# Altium schematic sheet 5.04.51805A02_RKUsb.SchDoc(5.04.51805A02_RKUsb)
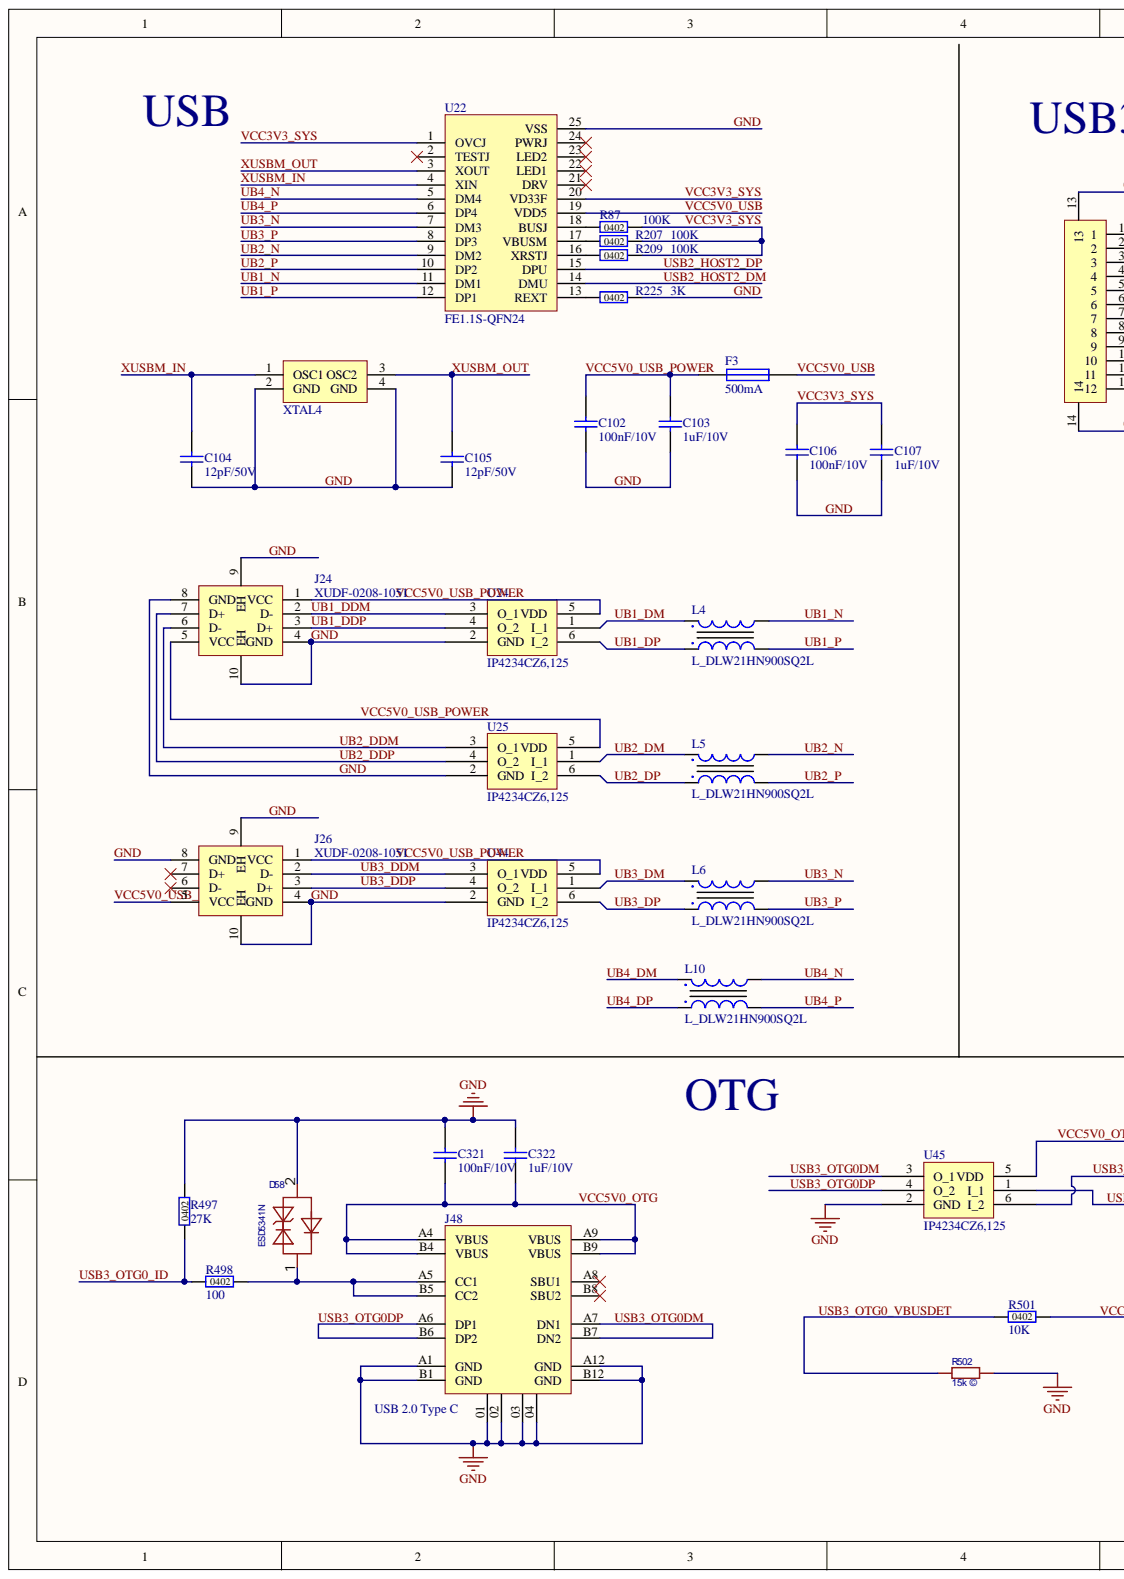
[diagram p0: 5.04.51805A02_RKUsb.SchDoc, left]
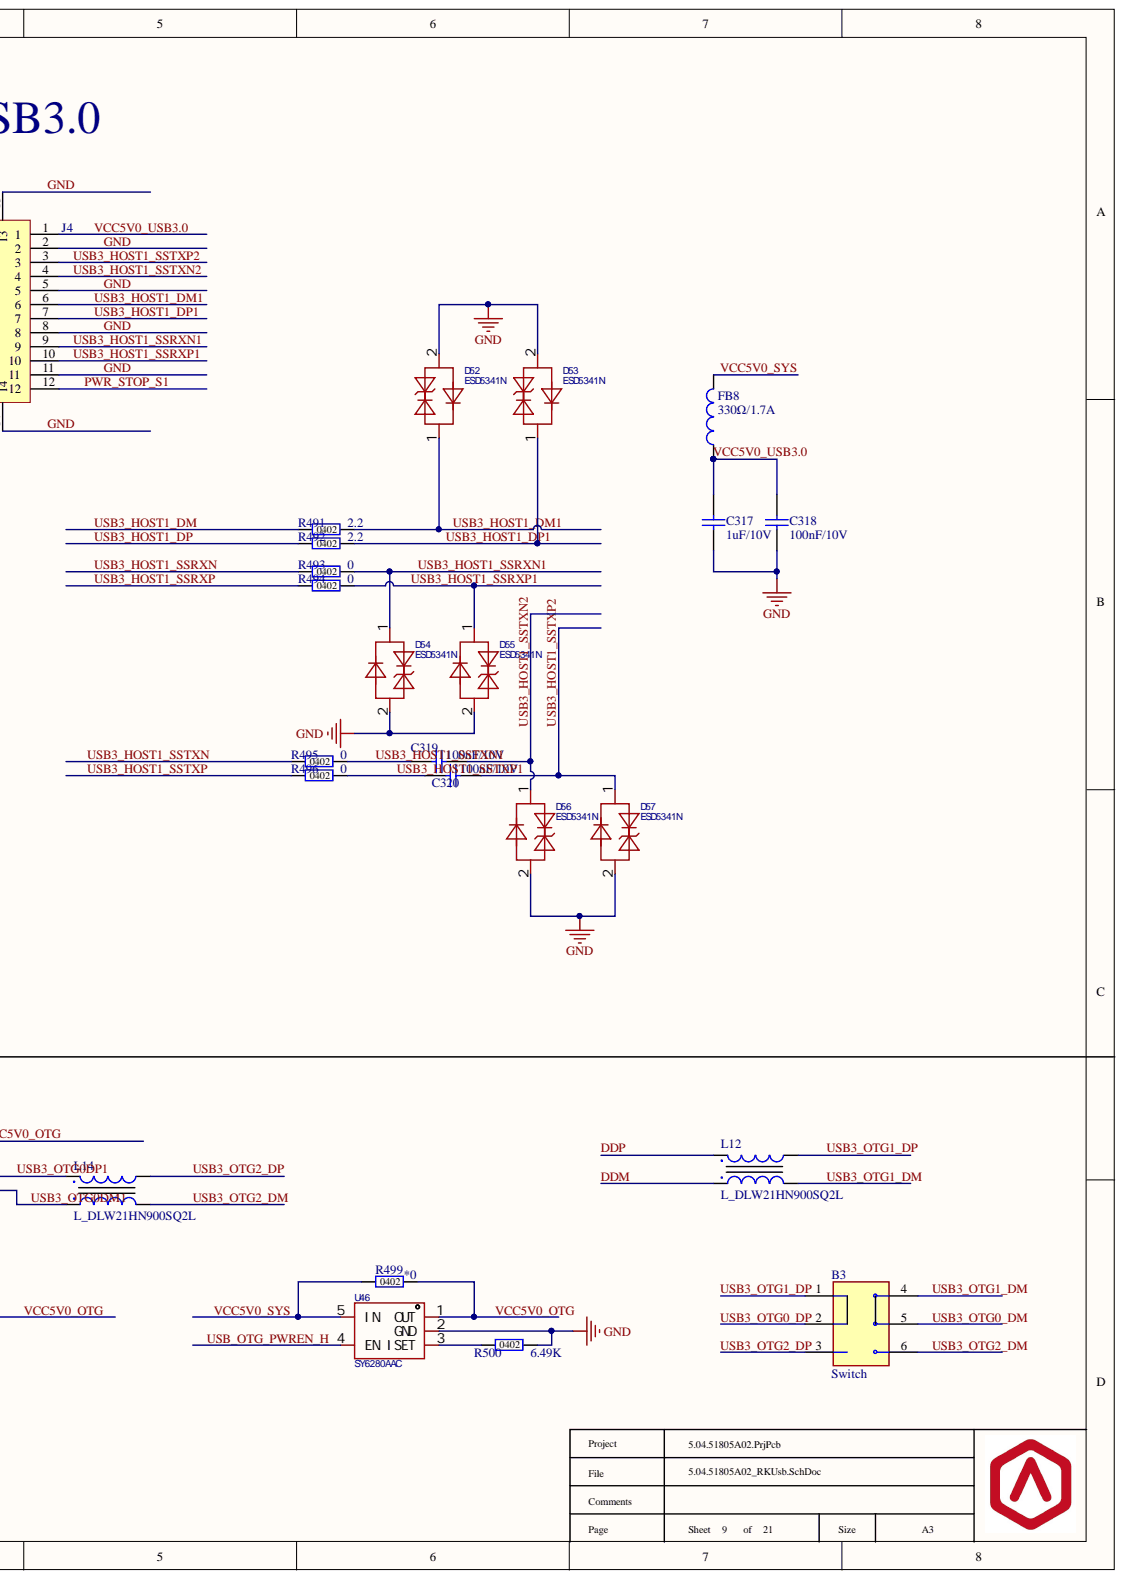
[diagram p1: 5.04.51805A02_RKUsb.SchDoc, right]

## Parts
B3  Switch  [SW-SMD_6P-L7.2-W3.5-P2.50-LS6.0-BL]
C102  100nF/10V  [C0402]
C103  1uF/10V  [C0402]
C104  12pF/50V  [C0402]
C105  12pF/50V  [C0402]
C106  100nF/10V  [C0402]
C107  1uF/10V  [C0402]
C317  1uF/10V  [C0402]
C318  100nF/10V  [C0402]
C319  100nF/10V  [C0402]
C320  100nF/10V  [C0402]
C321  100nF/10V  [C0402]
C322  1uF/10V  [C0402]
D52  ESD5341N  [DFN1006-2L-RD]
D53  ESD5341N  [DFN1006-2L-RD]
D54  ESD5341N  [DFN1006-2L-RD]
D55  ESD5341N  [DFN1006-2L-RD]
D56  ESD5341N  [DFN1006-2L-RD]
D57  ESD5341N  [DFN1006-2L-RD]
D58  ESD5341N  [DFN1006-2L-RD]
F3  500mA  [F1206]
FB8  330Ω/1.7A  [L0603]
J4  AFC01-S12FCA-00  [FPC-SMD_AFC01-S12FCA-00]  (value not drawn)
J24  XUDF-0208-1051  [USB-A-TH_XUDF-0208-1051]
J26  XUDF-0208-1051  [USB-A-TH_XUDF-0208-1051]
J48  USB 2.0 Type C  [USB-Type-C]
L4  L_DLW21HN900SQ2L  [DLW21HN900SQ2L]
L5  L_DLW21HN900SQ2L  [DLW21HN900SQ2L]
L6  L_DLW21HN900SQ2L  [DLW21HN900SQ2L]
L10  L_DLW21HN900SQ2L  [DLW21HN900SQ2L]
L12  L_DLW21HN900SQ2L  [DLW21HN900SQ2L]
L14  L_DLW21HN900SQ2L  [DLW21HN900SQ2L]
R87  100K  [R0402]
R207  100K  [R0402]
R209  100K  [R0402]
R225  3K  [R0402]
R491  2.2  [R0402]
R492  2.2  [R0402]
R493  0  [R0402]
R494  0  [R0402]
R495  0  [R0402]
R496  0  [R0402]
R497  27K  [R0402]
R498  100  [R0402]
R499  *0  [R0402]
R500  6.49K  [R0402]
R501  10K  [R0402]
R502  15kΩ  [R0402]
U22  FE1.1S-QFN24  [WQFN-24_L4.0-W4.0-P0.50-TL-EP2.7]
U24  IP4234CZ6,125  [TSOP6]
U25  IP4234CZ6,125  [TSOP6]
U44  IP4234CZ6,125  [TSOP6]
U45  IP4234CZ6,125  [TSOP6]
U46  SY6280AAC  [SOT-23-5_L3.0-W1.7-P0.95-LS2.8-BR]
XTAL4  X322512MOB4SI  [CRYSTAL-SMD_4P-L3.2-W2.5-BL]  (value not drawn)

## Nets
DDM: L12.1
DDP: L12.4
GND: C102.1 C103.1 C104.1 C105.1 C106.1 C107.1 C317.1 C318.1 C321.2 C322.2 D52.2 D53.2 D54.2 D55.2 D56.2 D57.2 D58.2 J4.2 J4.5 J4.8 J4.11 J4.13 J4.14 J24.4(GND) J24.8(GND) J24.9(EH) J24.10(EH) J26.4(GND) J26.8(GND) J26.9(EH) J26.10(EH) J48.01 J48.02 J48.03 J48.04 J48.A1(GND) J48.A12(GND) J48.B1(GND) J48.B12(GND) R225.2 R497.2 R500.2 R502.2 U22.25(VSS) U24.2(GND) U25.2(GND) U44.2(GND) U45.2(GND) U46.2(GND) XTAL4.2(GND) XTAL4.4(GND)
PWR_STOP_S1: J4.12
UB1_DDM: J24.2(D-) U24.3(O_1)
UB1_DDP: J24.3(D+) U24.4(O_2)
UB1_DM: L4.4 U24.1(I_1)
UB1_DP: L4.1 U24.6(I_2)
UB1_N: L4.3 U22.11(DM1)
UB1_P: L4.2 U22.12(DP1)
UB2_DDM: J24.6(D-) U25.3(O_1)
UB2_DDP: J24.7(D+) U25.4(O_2)
UB2_DM: L5.4 U25.1(I_1)
UB2_DP: L5.1 U25.6(I_2)
UB2_N: L5.3 U22.9(DM2)
UB2_P: L5.2 U22.10(DP2)
UB3_DDM: J26.2(D-) U44.3(O_1)
UB3_DDP: J26.3(D+) U44.4(O_2)
UB3_DM: L6.4 U44.1(I_1)
UB3_DP: L6.1 U44.6(I_2)
UB3_N: L6.3 U22.7(DM3)
UB3_P: L6.2 U22.8(DP3)
UB4_DM: L10.4
UB4_DP: L10.1
UB4_N: L10.3 U22.5(DM4)
UB4_P: L10.2 U22.6(DP4)
USB2_HOST2_DM: U22.14(DMU)
USB2_HOST2_DP: U22.15(DPU)
USB3_HOST1_DM: R491.1
USB3_HOST1_DM1: D52.1 J4.6 R491.2
USB3_HOST1_DP: R492.1
USB3_HOST1_DP1: D53.1 J4.7 R492.2
USB3_HOST1_SSRXN: R493.1
USB3_HOST1_SSRXN1: D54.1 J4.9 R493.2
USB3_HOST1_SSRXP: R494.1
USB3_HOST1_SSRXP1: D55.1 J4.10 R494.2
USB3_HOST1_SSTXN: R495.1
USB3_HOST1_SSTXN1: C319.1 R495.2
USB3_HOST1_SSTXN2: C319.2 D56.1 J4.4
USB3_HOST1_SSTXP: R496.1
USB3_HOST1_SSTXP1: C320.1 R496.2
USB3_HOST1_SSTXP2: C320.2 D57.1 J4.3
USB3_OTG0_DM: B3.5
USB3_OTG0_DP: B3.2
USB3_OTG0_ID: R497.1 R498.1
USB3_OTG0_VBUSDET: R501.1 R502.1
USB3_OTG0DM: J48.A7(DN1) J48.B7(DN2) U45.3(O_1)
USB3_OTG0DM1: L14.4 U45.1(I_1)
USB3_OTG0DP: J48.A6(DP1) J48.B6(DP2) U45.4(O_2)
USB3_OTG0DP1: L14.1 U45.6(I_2)
USB3_OTG1_DM: B3.4 L12.2
USB3_OTG1_DP: B3.1 L12.3
USB3_OTG2_DM: B3.6 L14.3
USB3_OTG2_DP: B3.3 L14.2
USB_OTG_PWREN_H: U46.4(EN)
VCC3V3_SYS: C106.2 C107.2 R87.2 R207.2 R209.2 U22.1(OVCJ) U22.20(VD33F)
VCC5V0_OTG: C321.1 C322.1 J48.A4(VBUS) J48.A9(VBUS) J48.B4(VBUS) J48.B9(VBUS) R499.2 R501.2 U45.5(VDD) U46.1(OUT)
VCC5V0_SYS: FB8.2 R499.1 U46.5(IN)
VCC5V0_USB: F3.2 U22.19(VDD5)
VCC5V0_USB3.0: C317.2 C318.2 FB8.1 J4.1
VCC5V0_USB_POWER: C102.2 C103.2 F3.1 J24.1(VCC) J24.5(VCC) J26.1(VCC) J26.5(VCC) U24.5(VDD) U25.5(VDD) U44.5(VDD)
XUSBM_IN: C104.2 U22.4(XIN) XTAL4.1(OSC1)
XUSBM_OUT: C105.2 U22.3(XOUT) XTAL4.3(OSC2)
NetD58_1: D58.1 J48.A5(CC1) J48.B5(CC2) R498.2
NetR87_1: R87.1 U22.18(BUSJ)
NetR207_1: R207.1 U22.17(VBUSM)
NetR209_1: R209.1 U22.16(XRSTJ)
NetR225_1: R225.1 U22.13(REXT)
NetR500_1: R500.1 U46.3(ISET)

Not connected: J26 6(D-) 7(D+); J48 A8(SBU1) B8(SBU2); U22 2(TESTJ) 21(DRV) 22(LED1) 23(LED2) 24(PWRJ)

## Library data of the parts
B3 = SS-3390S-L2: 开关,SS-3390S-L2,DC24V50mA,100mOhm,拨码,垂直,SMD,-40°C~85°C; Manufacturer1: XKB; Code: 570100XX; Component Kind: Standard; ManufacturerPartNumber1: SS-3390S-L2; Library Name: Raise3D_Switch&Optocoupler.IntLib
C102 C106 C318-C321 = C0402_100nF_10V: Ceramic Capacitor, 100nF, ±10%, 10V, -55°C~125°C, C0402; Manufacturer1: MuRata; ManufacturerPartNumber1: GRM155R71A104KA01D; Code: 5161C104; Component Kind: Standard; Library Name: Raise3D_Capacitor.IntLib
C103 C107 C317 C322 = C0402_1uF_10V: Ceramic Capacitor, 1uF, ±10%, 10V, -55°C~125°C, C0402; Manufacturer1: Yageo; ManufacturerPartNumber1: CC0402KRX5R6BB105; Code: 5161C105; Component Kind: Standard; Library Name: Raise3D_Capacitor.IntLib
C104 C105 = C0402_13pF_50V: Ceramic Capacitor, 12pF, ±5%, 50V, -55°C~125°C, C0402; Manufacturer1: FH; ManufacturerPartNumber1: 0402CG120J500NT; Code: C1547; Component Kind: Standard; Library Name: Raise3D_Capacitor.IntLib
D52-D58 = ESD5341N: 3.3A,5V,ESD; Manufacturer1: TECH PUBLIC(台舟); Component Kind: Standard; ManufacturerPartNumber1: ESD5341N; Library Name: QQQ.IntLib
F3 = Fuse_500mA: 保险丝,NANOSMDC050F/13.2-2,13.2V,500mA,1.1A,800mOhms,F1206,-40°C~85°C; Manufacturer1: LittelfuseInc.; Code: 56010002; Component Kind: Standard; ManufacturerPartNumber1: NANOSMDC050F/13.2-2; Library Name: Raise3D_Fuse.IntLib
FB8 = Bead_330Ohm_1.7A: Bead,MPZ1608S331A,330Ohm,1.7A,25%,L0603,-55°C~125°C; Manufacturer1: TDK; ManufacturerPartNumber1: MPZ1608S331ATA00; Code: 52010002; Component Kind: Standard; Library Name: Raise3D_Inductor&Bead.IntLib
J4 = AFC01-S12FCA-00: FFC/FPC连接器,12P,0.5mm,500mA,-40℃~85℃,SMD; Manufacturer1: JS; Code: C262661; Component Kind: Standard; ManufacturerPartNumber1: AFC01-S12FCA-00; Library Name: Raise3D_General.IntLib
J24 J26 = XUDF-0208-1051: USB连接器,USB 2.0 Type A,port=2,TH,TOP,1.5A,-25°C~85°C; Manufacturer1: LX; Code: 550300XX; Component Kind: Standard; ManufacturerPartNumber1: XUDF-0208-1051; Library Name: Raise3D_Connector.IntLib
J48 = JJ_CUS31738616001: USB连接器,USB 2.0 Type C,port=6,SMT,Side,3.0A,-30°C~85°C; Manufacturer1: CSCONN; Code: 55030010; Component Kind: Standard; ManufacturerPartNumber1: CUS31738616001; Library Name: Raise3D_Connector.IntLib
L4-L6 L10 L12 L14 = L_DLW21HN900SQ2L: Inductor,DLW21HN900SQ2L,300mA,25%,2.0mmX1.2mmX0.9mm,-40°C~125°C; Manufacturer1: MURATA; Code: 52030001; Component Kind: Standard; ManufacturerPartNumber1: DLW21HN900SQ2L; Library Name: Raise3D_Inductor&Bead.SCHLIB
R87 R207 R209 = R0402_100K: Resistor 100Kohm,1%,1/16W,R0402; Manufacturer1: Yageo; ManufacturerPartNumber1: RC0402FR-07100KL; Code: 5001100K; Component Kind: Standard; Manufacturer2: Samsung; ManufacturerPartNumber2: RC1005F104CS; Library Name: Raise3D_Resistor.IntLib
R225 = R0402_3K: Resistor 3Kohm,1%,1/16W,R0402; Manufacturer1: YAGEO; ManufacturerPartNumber1: RC0402FR-073KL; Code: 5001003K; Component Kind: Standard; Library Name: Raise3D_Resistor.IntLib
R491 R492 = R0402_2.2: Resistor 2.2ohm,1%,1/16W,R0402; Manufacturer1: Samsung; ManufacturerPartNumber1: RCS1005F2R2CS; Code: 500102R2; Component Kind: Standard; Library Name: Raise3D_Resistor.IntLib
R493-R496 R499 = R0402_0: Resistor 0ohm,1%,1/16W,R0402; Manufacturer1: Yageo; ManufacturerPartNumber1: RC0402FR-070RL; Code: 5001000R; Component Kind: Standard; Library Name: Raise3D_Resistor.IntLib
R497 = R0402_27K: Resistor 27Kohm,1%,1/16W,R0402; Manufacturer1: Yageo; ManufacturerPartNumber1: RC0402FR-0727KL; Code: 5001027K; Component Kind: Standard; Library Name: Raise3D_Resistor.IntLib
R498 = R0402_100: Resistor 100ohm,1%,1/16W,R0402; Manufacturer1: Yageo; ManufacturerPartNumber1: RC0402FR-07100RL; Code: 5001100R; Component Kind: Standard; Manufacturer2: Samsung; ManufacturerPartNumber2: RC1005F101CS; Library Name: Raise3D_Resistor.IntLib
R500 = R0402_6.49K: Resistor 6.49Kohm,1%,1/16W,R0402; Manufacturer1: Samsung; ManufacturerPartNumber1: RC1005F6491CS; Code: 50016K49; Component Kind: Standard; Library Name: Raise3D_Resistor.IntLib
R501 = R0402_10K: Resistor 10Kohm,1%,1/16W,R0402; Manufacturer1: Yageo; ManufacturerPartNumber1: RC0402FR-0710KL; Code: 5001010K; Component Kind: Standard; Manufacturer2: Samsung; ManufacturerPartNumber2: RC1005F103CS; Library Name: Raise3D_Resistor.IntLib
R502 = RC0402FR-0715KL: 厚膜电阻 15kΩ ±1% 62.5mW; Manufacturer1: YAGEO(国巨); Component Kind: Standard; ManufacturerPartNumber1: RC0402FR-0715KL; Library Name: QQQ.IntLib
U22 = FE1.1S-QFN24: HUB,FE1.1S-QFN24; Manufacturer1: TERMINUS; code: 591300XX; Component Kind: Standard; ManufacturerPartNumber1: FE1.1S-QFN24; Library Name: Raise3D_IC.IntLib
U24 U25 U44 U45 = ESD_IP4234CZ6,125: 接口IC,IP4234CZ6,125,ESD,1 Port,≤5.5V,TSOP6,-40°C~85°C; Manufacturer1: Nexperia; Code: 53040001; Component Kind: Standard; ManufacturerPartNumber1: IP4234CZ6,125
  U24 U25: Library Name: 5.04.51805A02.IntLib
  U44 U45: Library Name: Raise3D_Diode.IntLib
U46 = SY6280AAC: 供电开关; Manufacturer1: silergy(矽力杰); Component Kind: Standard; ManufacturerPartNumber1: SY6280AAC; Library Name: QQQ.IntLib
XTAL4 = X322512MOB4SI: 无源晶振,12MHz,12pF,-40℃~85℃,SMD3225-4P; Manufacturer1: YXC; Code: C70565; Component Kind: Standard; ManufacturerPartNumber1: X322512MOB4SI; Library Name: Raise3D_General.IntLib
